# Altium schematic sheet Sheet1.SchDoc
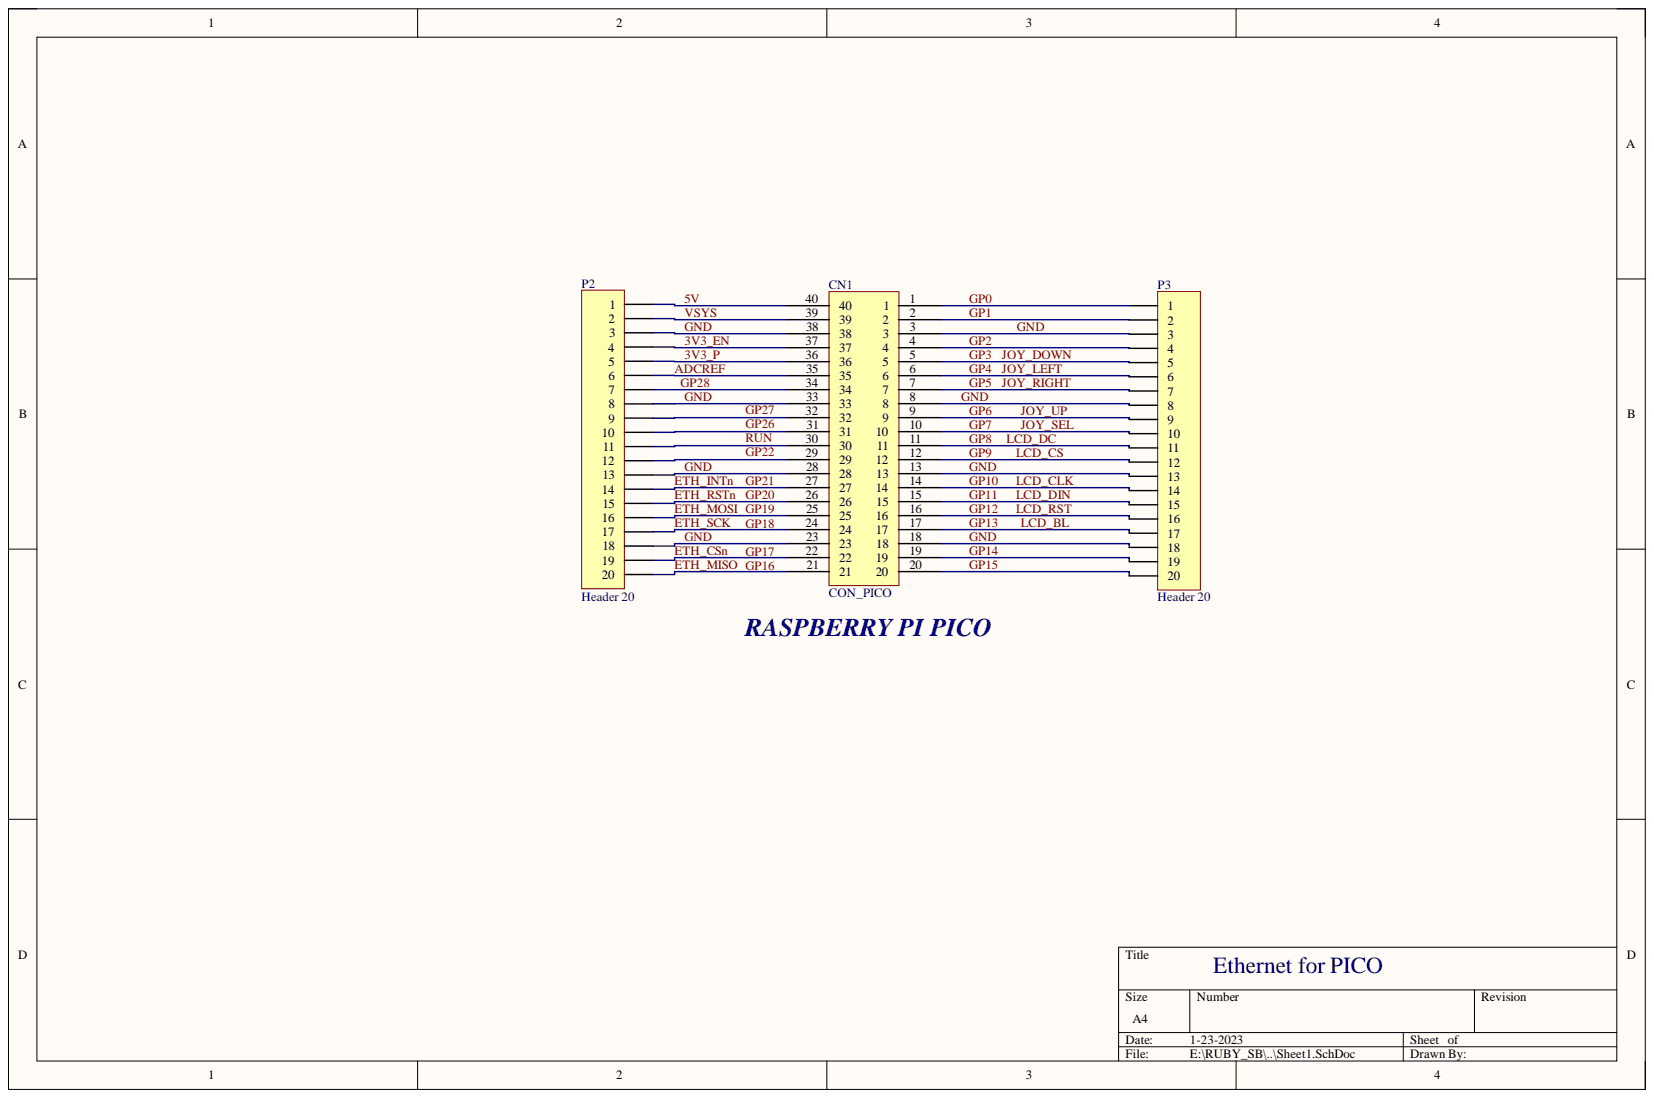
[diagram p0: Sheet1.SchDoc]

## Parts
CN1  CON_PICO  [SMD_HAT HEADER]
P2  Header 20  [20P TH]
P3  Header 20  [20P TH]

## Nets
3V3_EN: CN1.37 P2.4
3V3_P: CN1.36 P2.5
5V: CN1.40 P2.1
ADCREF: CN1.35 P2.6
ETH_CSn: CN1.22 P2.19
ETH_INTn: CN1.27 P2.14
ETH_MISO: CN1.21 P2.20
ETH_MOSI: CN1.25 P2.16
ETH_RSTn: CN1.26 P2.15
ETH_SCK: CN1.24 P2.17
GND: CN1.3 CN1.8 CN1.13 CN1.18 CN1.23 CN1.28 CN1.33 CN1.38 P2.3 P2.8 P2.13 P2.18 P3.3 P3.8 P3.13 P3.18
GP0: CN1.1 P3.1
GP1: CN1.2 P3.2
GP2: CN1.4 P3.4
GP3: CN1.5 P3.5
GP4: CN1.6 P3.6
GP5: CN1.7 P3.7
GP6: CN1.9 P3.9
GP7: CN1.10 P3.10
GP8: CN1.11 P3.11
GP9: CN1.12 P3.12
GP10: CN1.14 P3.14
GP11: CN1.15 P3.15
GP12: CN1.16 P3.16
GP13: CN1.17 P3.17
GP14: CN1.19 P3.19
GP15: CN1.20 P3.20
GP28: CN1.34 P2.7
VSYS: CN1.39 P2.2
NetCN1_29: CN1.29 P2.12
NetCN1_30: CN1.30 P2.11
NetCN1_31: CN1.31 P2.10
NetCN1_32: CN1.32 P2.9
GP16:
GP17:
GP18:
GP22:
GP26:
GP27:
RUN:

## Library data of the parts
CN1 = CON40_PICO TOP MALE BERG: Component Kind: Standard; Library Name: dxp_pasl_lib.IntLib
P2 P3 = Header 20: Header, 20-Pin; Component Kind: Standard; LatestRevisionDate: 17-Jul-2002; LatestRevisionNote: Re-released for DXP Platform.; Library Name: Miscellaneous Connectors.IntLib; Signal Integrity: Connector; Publisher: Altium Limited
